# Altium schematic sheet eRatPlatform_PowerSupply.SchDoc
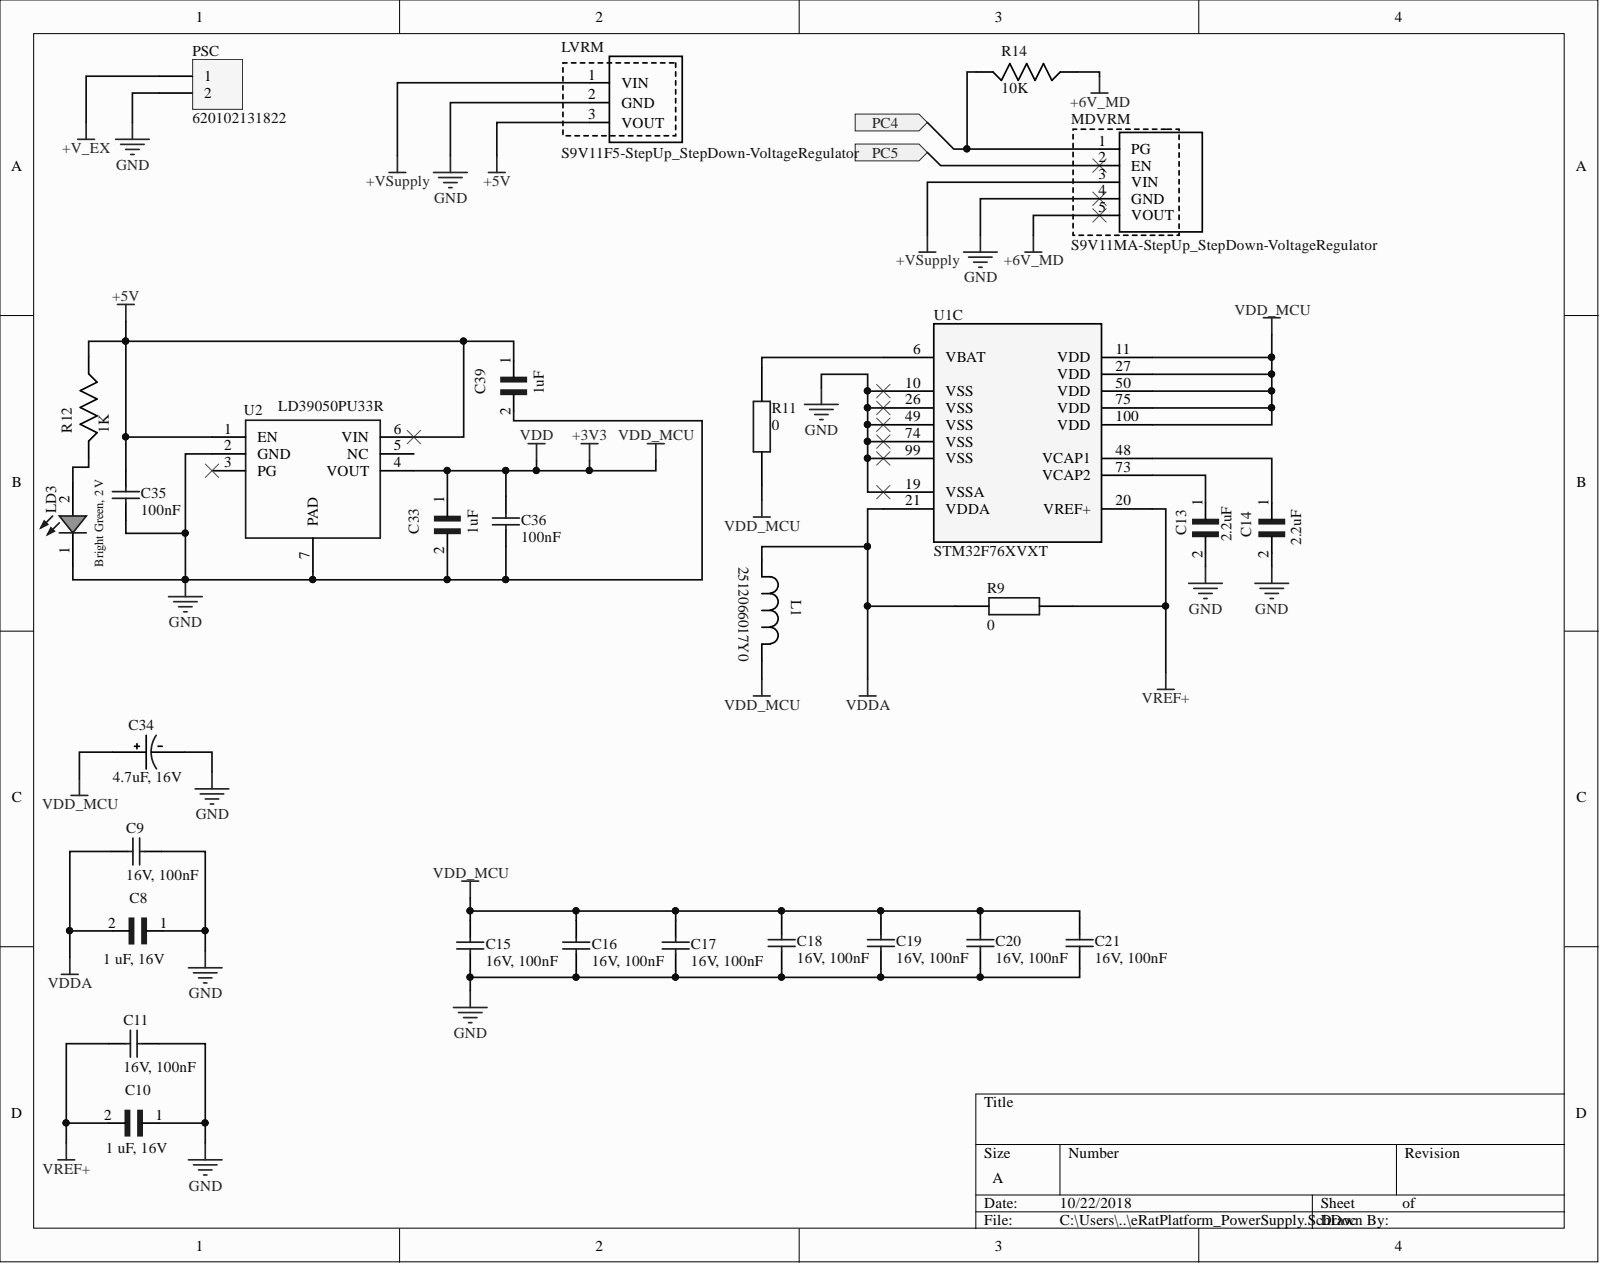
[diagram p0: eRatPlatform_PowerSupply.SchDoc]

## Parts
C8  1 uF, 16V  [CAPC1608X9N]
C9  16V, 100nF  value 100nF  [1206_3.2x1.6x0.8mm]
C10  1 uF, 16V  [CAPC1608X9N]
C11  16V, 100nF  value 100nF  [1206_3.2x1.6x0.8mm]
C13  value 2.2uF  [CAPC2012X100N]  (hidden comment: CGA4J3X7R1C225K125AB)
C14  value 2.2uF  [CAPC2012X100N]  (hidden comment: CGA4J3X7R1C225K125AB)
C15  16V, 100nF  [1206_3.2x1.6x0.8mm]
C16  16V, 100nF  value 100nF  [1206_3.2x1.6x0.8mm]
C17  16V, 100nF  value 100nF  [1206_3.2x1.6x0.8mm]
C18  16V, 100nF  value 100nF  [1206_3.2x1.6x0.8mm]
C19  16V, 100nF  value 100nF  [1206_3.2x1.6x0.8mm]
C20  16V, 100nF  value 100nF  [1206_3.2x1.6x0.8mm]
C21  16V, 100nF  value 100nF  [1206_3.2x1.6x0.8mm]
C33  1uF  [CAPC1608X9N]
C34  4.7uF, 16V  [CAPMP3216X166_TAJA475K016RNJ(Primary)]
C35  100nF  [1206_3.2x1.6x0.8mm]
C36  100nF  [1206_3.2x1.6x0.8mm]
C39  1uF  [CAPC1608X9N]
L1  2512066017Y0  [IND_25_1206]
LD3  Bright Green, 2 V  Forward Voltage: 2 V  [WL-SMTW_2214]
LVRM  S9V11F5-StepUp_StepDown-VoltageRegulator  [S9V11F5-StepUp_StepDown-VoltageRegulator]
MDVRM  S9V11MA-StepUp_StepDown-VoltageRegulator  [S9V11MA-StepUp_StepDown-VoltageRegulator]
PSC  620102131822  [620102131822]
R9  0  [RESC1608X55N]
R11  0  [RESC1608X55N]
R12  1K  [RESC1608X55]
R14  10K  [RESC1608X55]
U1  STM32F76XVXT  [LQFP-100_14X14mm]  (part C)
U2  LD39050PU33R  [SON95P300X300X100-7N-D]

## Ports
PC4, PC5

## Nets
+3V3: C15.2 C16.2 C17.2 C18.2 C19.2 C20.2 C21.2 C33.1 C34.POS C36.2 L1.1 R11.2 U1.11(VDD) U1.27(VDD) U1.50(VDD) U1.75(VDD) U1.100(VDD) U2.4(VOUT)
+5V: C35.2 C39.1 LVRM.3 R12.2 U2.1(EN) U2.6(VIN)
+6V_MD: MDVRM.5(VOUT) R14.2
+V_EX: PSC.1
+VSupply: LVRM.1 MDVRM.3(VIN)
GND: C8.1 C9.1 C10.1 C11.2 C13.2 C14.2 C15.1 C16.1 C17.1 C18.1 C19.1 C20.1 C21.1 C33.2 C34.NEG C35.1 C36.1 C39.2 LD3.1 LVRM.2 MDVRM.4(GND) PSC.2 U1.10(VSS) U1.19(VSSA) U1.26(VSS) U1.49(VSS) U1.74(VSS) U1.99(VSS) U2.2(GND) U2.7(PAD)
VDDA: C8.2 C9.2 L1.2 R9.1 U1.21(VDDA)
VREF+: C10.2 C11.1 R9.2 U1.20(VREF+)
PC4: MDVRM.1(PG) R14.1 ; port PC4
PC5: MDVRM.2(EN) ; port PC5
NetC13_1: C13.1 U1.73(VCAP2)
NetC14_1: C14.1 U1.48(VCAP1)
NetLD3_2: LD3.2 R12.1
NetR11_1: R11.1 U1.6(VBAT)

Not connected: U2 3(PG) 5(NC)

## Library data of the parts
C8 C10 C33 C39 = GRM185R61C105KE44D: 0603 1 uF 16V Â±10% Tolerance X5R Surface Mount Multilayer Ceramic Capacitor; MF: Murata; Availability: Unavailable; Component Kind: Standard; Library Name: GRM185R61C105KE44D.IntLib; MP: GRM185R61C105KE44D; Package: 0603 Murata; Price: None; SnapEDA_Link: https://www.snapeda.com/parts/GRM185R61C105KE44D/Murata%20Electronics%20North%20America/view-part/?ref=snap
C9 C11 C15-C21 C35 C36 = 885012208030: WCAP-CSGP Ceramic Capacitors, 1206, X7R, 16V, 100nF; Rated Voltage: 16V DC; Ceramic Type: X7R; Component Kind: Standard; ComponentLink1Description: Manufacturer; ComponentLink1URL: http://www.we-online.de; ComponentLink2Description: Datasheet; ComponentLink2URL: http://katalog.we-online.de/pbs/datasheet/885012208030.pdf; Library Name: ALTIUM-WCAP-CSGP-1206-rev17b.IntLib; Operating Temperature: -55 °C to +125 °C; Part Number: 885012208030; Published Date: Oct. 2017; Size: 1206; Publisher: Wurth Elektronik
  C15 C35 C36: Value: 100nF
C13 C14 = CGA4J3X7R1C225K125AB: SMD Multilayer Ceramic Capacitor, AEC-Q200 CGA Series, 2.2 uF, 10%, X7R, 16 V, 0805 [2012 Metric]; MF: TDK; Component Kind: Standard; Digi-Key_Part_Number: 445-5691-1-ND; Digi-Key_Purchase_URL: https://www.digikey.pl/product-detail/en/tdk-corporation/CGA4J3X7R1C225K125AB/445-5691-1-ND/2443731?utm_source=snapeda&utm_medium=aggregator&utm_campaign=symbol; Library Name: CGA4J3X7R1C225K125AB.IntLib; MP: CGA4J3X7R1C225K125AB; Package: 0805 TDK; SnapEDA_Link: https://www.snapeda.com/parts/CGA4J3X7R1C225K125AB/TDK-Lambda%20Americas%20Inc/view-part/?ref=snap
C34 = TAJA475K016RNJ: Cap Tant Solid 4.7uF 16V A CASE 10% _3.2 X 1.6 X 1.6mm_ SMD 3216-18 4 Ohm 125Â°C T/R; MF: AVX; Availability: Good; Component Kind: Standard; Library Name: TAJA475K016RNJ.IntLib; MP: TAJA475K016RNJ; Package: 1206 AVX; Price: 0.21 USD; SnapEDA_Link: https://www.snapeda.com/parts/TAJA475K016RNJ/AVX%20/%20Kyocera/view-part/?ref=snap
L1 = 2512066017Y0: Imported; Built_By: EMA_UL_Team; C: Copyright (C) 2015 Accelerated Designs. All rights reserved; Type: DEV; Vendor: Fair-Rite; Component Kind: Standard; Library Name: 2512066017Y0.IntLib; Manufacturer_Part_Number: 2512066017Y0; RefDes: RefDes; Value: Value
LD3 = 150224VS73100A: WL-SMTW SMD Mono-color TOP LED Waterclear, size 2214, Bright Green, 2 V, 120°; Dominant Wavelength: 570 nm; Luminous Intensity: 70 mcd; Peak Wavelength: 572 nm; Component Kind: Standard; ComponentLink1Description: Manufacturer; ComponentLink1URL: http://www.we-online.de; ComponentLink2Description: Datasheet; ComponentLink2URL: http://katalog.we-online.de/led/datasheet/150224VS73100A.pdf; Emitting Color: Bright Green; Library Name: ALTIUM-WL-SMTW-rev18a.IntLib; Operating Temperature: -40 to 85 degC; Part Number: 150224VS73100A; Published Date: March 2018; Size: 2214; Viewing Angle: 120°; Publisher: Wurth Elektronik
PSC = 620102131822: WR-WTB 2.00mm SMT Male Horizontal Shrouded Header, 2p; Pin Number: 2; Component Kind: Standard; ComponentLink1Description: Manufacturer; ComponentLink1URL: http://www.we-online.de; ComponentLink2Description: Datasheet; ComponentLink2URL: http://katalog.we-online.de/em/datasheet/6201xx131822.pdf; Library Name: ALTIUM-WTB_2_00_SMT_MALE_HORIZONTAL_SHROUDED_HEADER_6201XX131822-rev17a.IntLib; Part Number: 620102131822; Pitch: 2.00 mm; Published Date: June 2017; Publisher: Wurth Elektronik
R9 R11 = CR0603-J_-000ELF: BOURNS - CR0603-J/-000ELF - RES, THICK FILM, 0R, 5%, 0.1W, 0603; Allied_Number: 70154688; Geometry.Height: 0.55mm; Allied Price/Stock: https://www.alliedelec.com/bourns-cr0603-j-000elf/70154688/; Arrow Part Number: CR0603-J/-000ELF; Arrow Price/Stock: https://www.arrow.com/en/products/cr0603-j-000elf/bourns; Component Kind: Standard; Datasheet Link: https://www.bourns.com/pdfs/chpreztr.pdf; Library Name: CR0603-J_-000ELF.IntLib; Manufacturer_Name: Bourns; Manufacturer_Part_Number: CR0603-J/-000ELF
R12 = ERJ-3EKF1001V: Res Thick Film 0603 1K Ohm 1% 0.1W_1/10W_ Ã‚Â±100ppm/Ã‚Â°C Molded SMD Automotive Punched T/R; MF: Panasonic; Component Kind: Standard; Digi-Key_Part_Number: P1.00KHCT-ND; Digi-Key_Purchase_URL: https://www.digikey.pl/product-detail/en/panasonic-electronic-components/ERJ-3EKF1001V/P1.00KHCT-ND/198071?utm_source=snapeda&utm_medium=aggregator&utm_campaign=symbol; Library Name: ERJ-3EKF1001V.IntLib; MP: ERJ-3EKF1001V; Package: 0603 Panasonic; SnapEDA_Link: https://www.snapeda.com/parts/ERJ-3EKF1001V/Panasonic%20Electronic%20Components/view-part/?ref=snap
R14 = ERJ-3EKF1002V: Res Thick Film 0603 10K Ohm 1% 0.1W_1/10W_ Ã‚Â±100ppm/Ã‚Â°C Molded SMD Automotive Punched T/R; MF: Panasonic; Component Kind: Standard; Digi-Key_Part_Number: P10.0KHCT-ND; Digi-Key_Purchase_URL: https://www.digikey.pl/product-detail/en/panasonic-electronic-components/ERJ-3EKF1002V/P10.0KHCT-ND/198102?utm_source=snapeda&utm_medium=aggregator&utm_campaign=symbol; Library Name: ERJ-3EKF1002V.IntLib; MP: ERJ-3EKF1002V; Package: 0603 Panasonic; SnapEDA_Link: https://www.snapeda.com/parts/ERJ-3EKF1002V/Panasonic%20Electronic%20Components/view-part/?ref=snap
U1 = STM32F76XVXT: Imported; C: Copyright (C) 2016 Accelerated Designs. All rights reserved; Vendor: STMicroelectronics; Component Kind: Standard; Library Name: STM32F767VIT6.IntLib; Manufacturer_Part_Number: stm32f76xvxt; RefDes: RefDes; Type: Type; Value: Value; Copyright: Copyright (C) 2016 Accelerated Designs. All rights reserved
U2 = LD39050PU33R: STMICROELECTRONICS - LD39050PU33R - LDO, FIXED, 3.3V, 0.5A, DFN-6; Geometry.Height: 1mm; Arrow Part Number: LD39050PU33R; Arrow Price/Stock: https://www.arrow.com/en/products/ld39050pu33r/stmicroelectronics; Component Kind: Standard; Datasheet Link: https://componentsearchengine.com/Datasheets/2/LD39050PU33R.pdf; Library Name: LD39050PU33R.IntLib; Manufacturer_Name: STMicroelectronics; Manufacturer_Part_Number: LD39050PU33R
LVRM = S9V11F5-StepUp_StepDown-VoltageRegulator: Component Kind: Standard; Library Name: S9V11F5-StepUp_StepDown-VoltageRegulator.IntLib
MDVRM = S9V11MA-StepUp_StepDown-VoltageRegulator: Component Kind: Standard; Library Name: S9V11MA-StepUp_StepDown-VoltageRegulator.IntLib
